# Altium schematic sheet CVM.SchDoc(CVM)
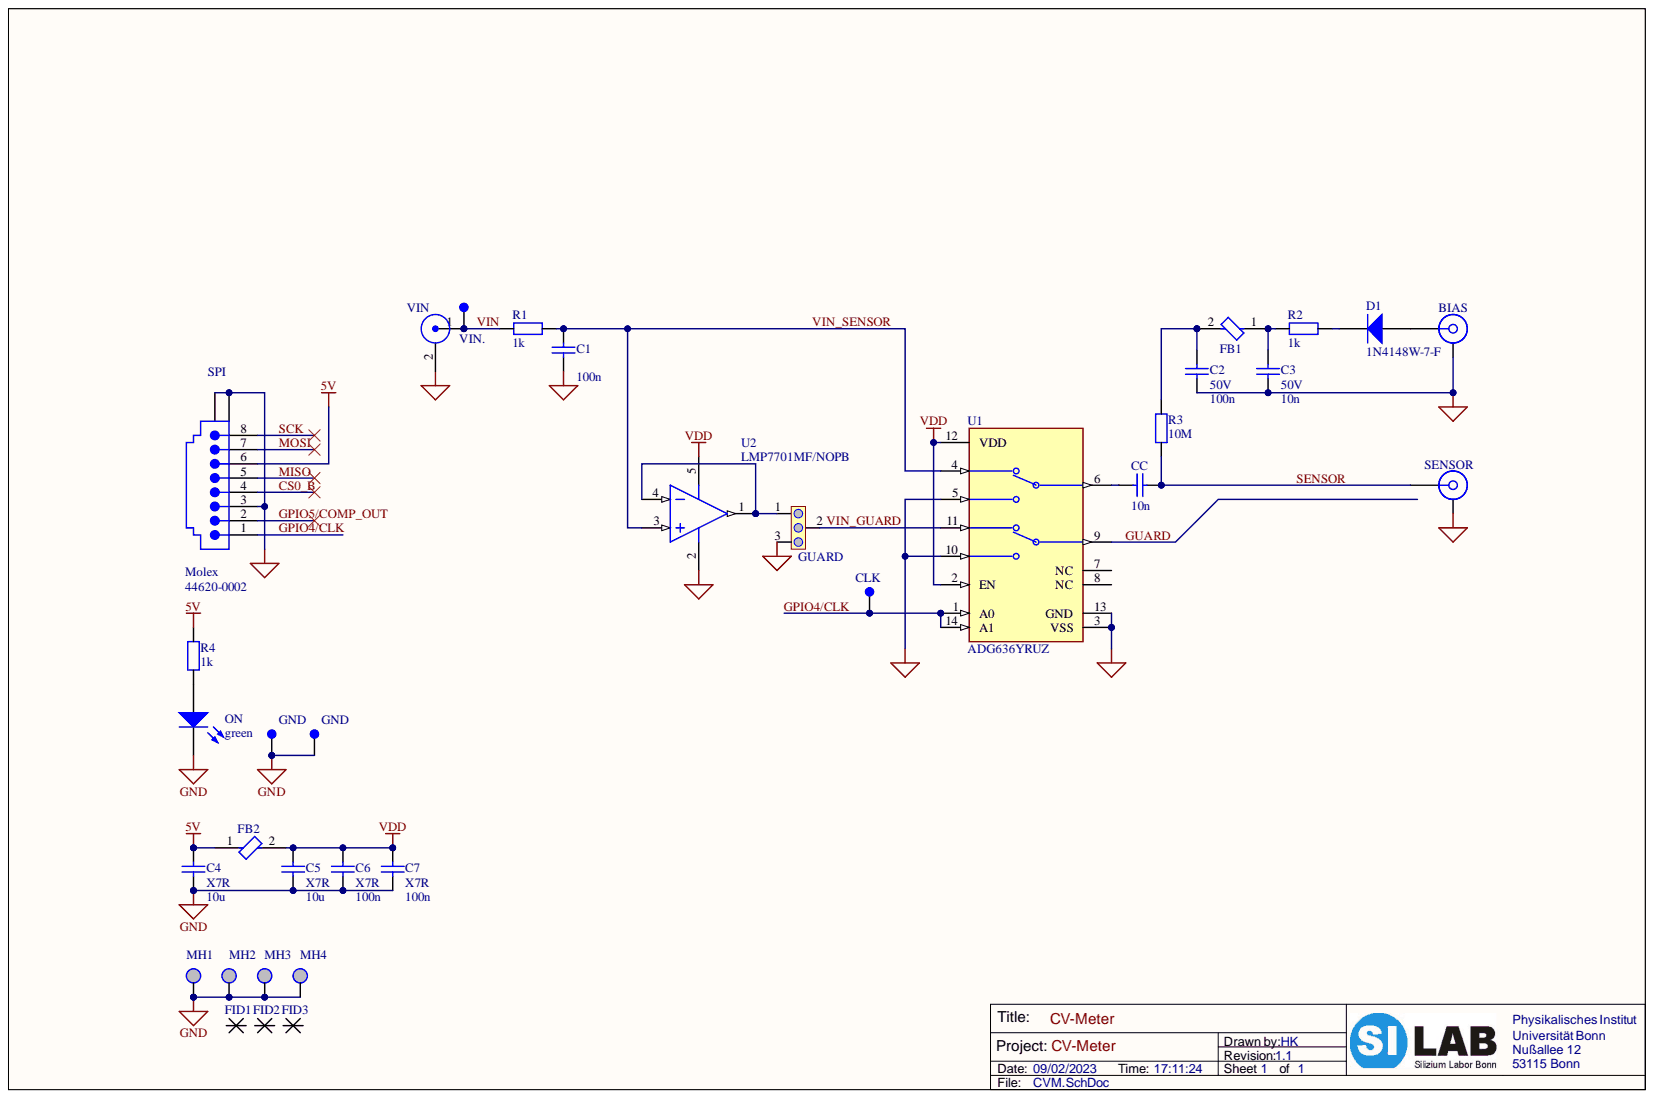
[diagram p0: CVM.SchDoc]

## Parts
BIAS  71K201-400A5  [ROS-71K201-400A5_V]  (value not drawn)
C1  value 100n  [C0603_imp_N]  (hidden comment: X7R)
C2  50V  value 100n  [C0603_imp_N]
C3  50V  value 10n  [C0603_imp_N]
C4  X7R  value 10u  [C0603_imp_N]
C5  X7R  value 10u  [C0603_imp_N]
C6  X7R  value 100n  [C0603_imp_N]
C7  X7R  value 100n  [C0603_imp_N]
CC  value 10n  [C0603_imp_N]  (hidden comment: X7R)
CLK  -  [Testpoint 1.0mm]  (value not drawn)
D1  1N4148W-7-F  [DIOD-SOD123-2_V]
FB1  BLM18PG121SN1D  [FP-BLM18-0_15-t0_8-IPC_A]  (value not drawn)
FB2  BLM18PG121SN1D  [FP-BLM18-0_15-t0_8-IPC_A]  (value not drawn)
FID1  xxx  [Fiducial]  (value not drawn)
FID2  xxx  [Fiducial]  (value not drawn)
FID3  xxx  [Fiducial]  (value not drawn)
GND  -  [Testpoint 1.0mm]  (value not drawn)
GND  -  [Testpoint 1.0mm]  (value not drawn)
GUARD  -  [HDR 1x3]  (value not drawn)
MH1  -  [MH_M3_3.1]  (value not drawn)  (no BOM)
MH2  -  [MH_M3_3.1]  (value not drawn)  (no BOM)
MH3  -  [MH_M3_3.1]  (value not drawn)  (no BOM)
MH4  -  [MH_M3_3.1]  (value not drawn)  (no BOM)
ON  green  [0603_A]
R1  R  value 1k  [R0603_imp_N]
R2  R  value 1k  [R0603_imp_N]
R3  R  value 10M  [R0603_imp_N]
R4  R  value 1k  [R0603_imp_N]
SENSOR  71K101-400A5  [ROS-71K101-400A5_V]  (value not drawn)
SPI  Comment_1: Molex  [44620-0002]  (hidden comment: -)
U1  ADG636YRUZ  [FP-RU-14-IPC_C]
U2  LMP7701MF/NOPB  [DBV0005A_L]
VIN  -  [LEMO horiz.]  (value not drawn)
VIN.  -  [Testpoint 1.0mm]  (value not drawn)

## Nets
5V: C4.1 FB2.1 R4.2 SPI.6
GND: BIAS.MH C1.2 C2.1 C3.1 C4.2 C5.2 C6.2 C7.2 GND.1 GUARD.3 MH1.0 MH2.0 MH3.0 MH4.0 ON.1 SENSOR.MH SPI.3 SPI.MH1 SPI.MH2 U1.3(VSS) U1.5 U1.10 U1.13(GND) U2.2 VIN.2
VDD: C5.1 C6.1 C7.1 FB2.2 U1.2(EN) U1.12(VDD) U2.5
CS0_B: SPI.4
GPIO4/CLK: CLK.1 SPI.1 U1.1(A0) U1.14(A1)
GPIO5/COMP_OUT: SPI.2
GUARD: U1.9
MISO: SPI.5
MOSI: SPI.7
SCK: SPI.8
SENSOR: CC.2 R3.2 SENSOR.1
VIN: R1.2 VIN.1 VIN..1
VIN_GUARD: GUARD.2 U1.11
VIN_SENSOR: C1.1 R1.1 U1.4 U2.3
NetBIAS_1: BIAS.1 D1.1
NetC2_2: C2.2 FB1.2 R3.1
NetC3_2: C3.2 FB1.1 R2.2
NetCC_1: CC.1 U1.6
NetD1_2: D1.2 R2.1
NetGUARD_1: GUARD.1 U2.1 U2.4
NetON_2: ON.2 R4.1

Not connected: U1 7(NC) 8(NC)

## Library data of the parts
BIAS = CMP-0757-00003-1: 75R BNC Right Angle Jack, Up to 4 GHz, -65 to 165 degC; Manufacturer: Rosenberger; Design Note: Footprint in library is a generic example - only application specific footprint delivers High Performance; Component Kind: Standard; ComponentLink1Description: Manufacturer URL; ComponentLink1URL: http://www.rosenberger.de; ComponentLink2Description: Datasheet; ComponentLink2URL: http://rosenberger.de/ok/images/documents/db/71K201-400A5.pdf; ComponentLink3Description: Package Specification; ComponentLink3URL: http://rosenberger.de/ok/images/documents/db/71K201-400A5.pdf; ComponentLink4Description: Series Overview / Design Note; ComponentLink4URL: http://rosenberger.de/ok/images/documents/spez/71.pdf; ComponentLink5Description: Webshop / RFQ; ComponentLink5URL: http://www.rosenberger.com/en/contact/sales/; DatasheetVersion: d00; Library Name: Altium Content Vault; Mounting Technology: Through Hole; PackageDescription: 75R BNC, Right Angle Jack, 12 x 23.1 x 14.5 mm; PackageReference: 71K201-400A5; PackageVersion: d00; PartNumber: 71K201-400A5
C1-C7 CC = C: Capacitor; Class: Passive; Constraints: 6.3V; Type_1: SMD Chip Capacitor; Comment_1: -; Component Kind: Standard; HelpURL: -; LatestRevisionDate: 27-Nov-2007; PackageDescription: -; Signal Integrity: Capacitor; Simulation: Capacitor; Library Name: Silab_Lib.IntLib; Publisher: Silab J.S.
CLK GND GND VIN. = Testpoint_gefüllt: Solderpad, Einzelner Kontakt; Class: Connector; Comment_1: -; Component Kind: Standard; Constraints: -; HelpURL: -; LatestRevisionDate: 24-Sep-2008; PackageDescription: -; Type_1: -; Value: -; Library Name: Silab_Lib.IntLib; Publisher: Silab C.H.
D1 = CMP-2000-04932-2: Fast Switching Diode, 100 V, 0.15 A, -65 to 150 degC, 2-Pin?SOD123, RoHS, Tape and Reel; Brand: DiodesIncorporated; Configuration: Single; If - Forward Current: 0.15A; Ir - Reverse Current: 1uA; Max Surge Current: 2A; Peak Reverse Voltage: 100V; Recovery Time: 4ns; Unit Weight: 0.000353oz; Vf - Forward Voltage: 1.25V; Component Kind: Standard; Datasheet URL: http://www.farnell.com/datasheets/1915529.pdf; Datasheet Version: Rev. 24-2, 10/2013; Factory Pack Quantity: 3000; Height: 1.25mm; Length: 2.85mm; Library Name: Altium Content Vault; Manufacturer: DiodesIncorporated; Manufacturer URL: http://www.diodes.com/; Maximum Operating Temperature: +150C; Minimum Operating Temperature: -65C; Mounting Style: SMD/SMT; Operating Temperature Range: -65Cto+150C; Package / Case: SOD-123; Package Reference: SOD123-2; Package URL: http://www.diodes.com/datasheets/ds18004.pdf; Packaging: Reel; Product: SwitchingDiodes; Product Category: Diodes-GeneralPurpose,Power,Switching; RoHS: True; Series: 1N4148; Type: SwitchingDiode; Width: 1.7mm
FB1 FB2 = CMP-0686-00557-7: Chip Ferrite Bead, 0603, 120Ω @ 100MHz, 0.05Ω, 25%, 2A; DC Resistance (DCR): 50mR; Impedance: 120R; Material: Steel; Number of Circuits: 1; Shielding: Unshielded; Case Code (Imperial): 0603; Case Code (Metric): 1608; Case/Package: 0603; Component Kind: Standard; Core Material: Ferrite; Current Rating: 2A; DC Current: 2A; Depth: 0.8mm; Frequency: 100MHz; Height: 0.8mm; Lead Free: Lead Free; Length: 1.6mm; Library Name: Altium Content Vault; Max Current Rating: 2A; Max DC Current: 2A; Max Operating Temperature: 125°C; Min Operating Temperature: -55°C; Number of Lines: 1; Number of Pins: 2; Package Quantity: 1; Packaging: Tape and Reel; Radiation Hardening: No; REACH SVHC: No SVHC; RoHS Compliant: Yes; Termination: SMD/SMT; Test Frequency: 100MHz; Tolerance: 25%; Width: 0.8mm
FID1-FID3 = Fiducial: Class: Passive; Comment_1: xxx; Component Kind: Standard; Constraints: xxx; Description: Fiducial; LatestRevisionDate: 09-Aug-2013; PackageDescription: xxx; Type_1: xxx; Value: xxx; HelpURL: http://www.   ...  or    X:\DXP\Datasheets\ ...; Library Name: Silab_Lib.IntLib; Publisher: Silab VF
GUARD = JUMPER 1X3S: Jumper, Solderbridge 1x3; Class: Connector; Comment_1: -; Component Kind: Standard; Constraints: -; HelpURL: -; LatestRevisionDate: 24-Sep-2008; PackageDescription: -; Type_1: -; Value: -; Library Name: Silab_Lib.IntLib; Publisher: Silab C.H.
MH1-MH4 = MountingHole: Class: Connector; Comment_1: -; Constraints: -; HelpURL: -; LatestRevisionDate: 24-Sep-2008; PackageDescription: -; Type_1: -; Library Name: Silab_Lib.IntLib; Publisher: Silab J.S.
ON = CMP-1426-00002-1: SMD mono-color Chip LED, WL-SMCW, Green; Manufacturer: Wuerth Elektronik; PartNumber: 150060GS75000; Color: Green; Component Kind: Standard; ComponentLink1Description: Manufacturer URL; ComponentLink1URL: http://www.we-online.com; ComponentLink2Description: Datasheet; ComponentLink2URL: http://katalog.we-online.com/led/datasheet/150060GS75000.pdf; Library Name: Altium Content Vault; PackageReference: 0603_A
R1-R4 = R: SMD Chip Resistor; Class: Passive; Constraints: 1%; Comment_1: -; Component Kind: Standard; HelpURL: -; LatestRevisionDate: 27-Nov-2007; PackageDescription: -; Signal Integrity: Resistor; Simulation: Resistor; Type_1: SMD Chip Resistor; Library Name: Silab_Lib.IntLib; Publisher: Silab J.S.
SENSOR = CMP-0757-00001-1: 75R BNC Straight Jack, Up to 4 GHz, -65 to 165 degC; Manufacturer: Rosenberger; Design Note: Footprint in library is a generic example - only application specific footprint delivers High Performance; Component Kind: Standard; ComponentLink1Description: Manufacturer URL; ComponentLink1URL: http://www.rosenberger.de; ComponentLink2Description: Datasheet; ComponentLink2URL: http://rosenberger.de/ok/images/documents/db/71k101-400A5.pdf; ComponentLink3Description: Package Specification; ComponentLink3URL: http://rosenberger.de/ok/images/documents/db/71K101-400A5.pdf; ComponentLink4Description: Series Overview / Design Note; ComponentLink4URL: http://rosenberger.de/ok/images/documents/spez/71.pdf; ComponentLink5Description: Webshop / RFQ; ComponentLink5URL: http://www.rosenberger.com/en/contact/sales/; DatasheetVersion: a00; Library Name: Altium Content Vault; Mounting Technology: Through Hole; PackageDescription: 75R BNC, Straight Jack, 12 x 12 x 15 mm; PackageReference: 71K101-400A5; PackageVersion: a00; PartNumber: 71K101-400A5
SPI = RJ-45 Buchse: Modular Jack, Right Angle RJ-45, Low Profile, Inverted, with all Panel Grounding Tabs, Shielded with RJ-11 Keepout Feature; Class: Connector; Component Kind: Standard; Constraints: -; HelpURL: http://www.molex.com/cmc_upload/common_files/1212003_mx44620.pdf; LatestRevisionDate: 24-Sep-2008; Signal Integrity: Modular Jack; Type_1: 44620-0002; Value: -; Library Name: Silab_Lib.IntLib; Publisher: Silab C.H.
U1 = CMP-0792-00216-2: IC SWITCH DUAL SPDT 14TSSOP; -3db Bandwidth: 610MHz; Drain to Source Resistance: 85R; Dual Supply Voltage: 5V; Interface: Parallel; Max Dual Supply Voltage: 5.5V; Max Power Dissipation: 10uW; Max Supply Current: 1uA; Min Dual Supply Voltage: 2.7V; Number of Bits: 2; Number of Outputs: 4; On-State Resistance: 115R; Supply Type: Single; Turn-Off Delay Time: 80ns; Turn-On Delay Time: 135ns; Bandwidth: 610MHz; Case/Package: TSSOP; Component Kind: Standard; Height: 1mm; Lead Free: Contains Lead; Length: 5mm; Library Name: Altium Content Vault; Max Operating Temperature: 125°C; Max Supply Voltage: 5.5V; Min Operating Temperature: -40°C; Min Supply Voltage: 2.7V; Nominal Supply Current: 1uA; Number of Channels: 2; Number of Circuits: 2; Number of Inputs: 2; Number of Pins: 14; Package Quantity: 96; Packaging: Rail/Tube; Power Dissipation: 10uW; Radiation Hardening: No; REACH SVHC: No SVHC; RoHS Compliant: Yes; Series: -; Supply Current: 1uA; Throw Configuration: SPDT; Width: 4.4mm
U2 = CMP-0055-01288-3: Precision, CMOS Input, RRIO, Wide Supply Range Amplifier, 5-pin SOT-23, Pb-Free; Manufacturer: Texas Instruments; CompType: Amplifiers; PackageTopMarking: AC2A*XTT; PowerWise: N; Component Kind: Standard; ComponentLink1Description: Datasheet URL; ComponentLink1URL: http://www.ti.com/general/docs/lit/getliterature.tsp?genericPartNumber=LMP7701&fileType=pdf; Library Name: Altium Content Vault; Package Reference: DBV0005A; PackageDescription: Molded SOT-23, .115 x .063 x .040 in Body, 5 Lead, .0375 in Pitch; PinCount: 5; RoHS: Y
VIN = LEMO coax: LEMO/BNC Buchse, aufrecht od. gewinkelt; Class: Connector; Comment_1: LEMO; Type_1: EPL.00.250.NTN; Component Kind: Standard; Constraints: -; HelpURL: -; LatestRevisionDate: 24-Sep-2008; PackageDescription: -; Value: -; Library Name: Silab_Lib.IntLib; Publisher: Silab C.H.
